# Altium schematic sheet HF_Control.SchDoc
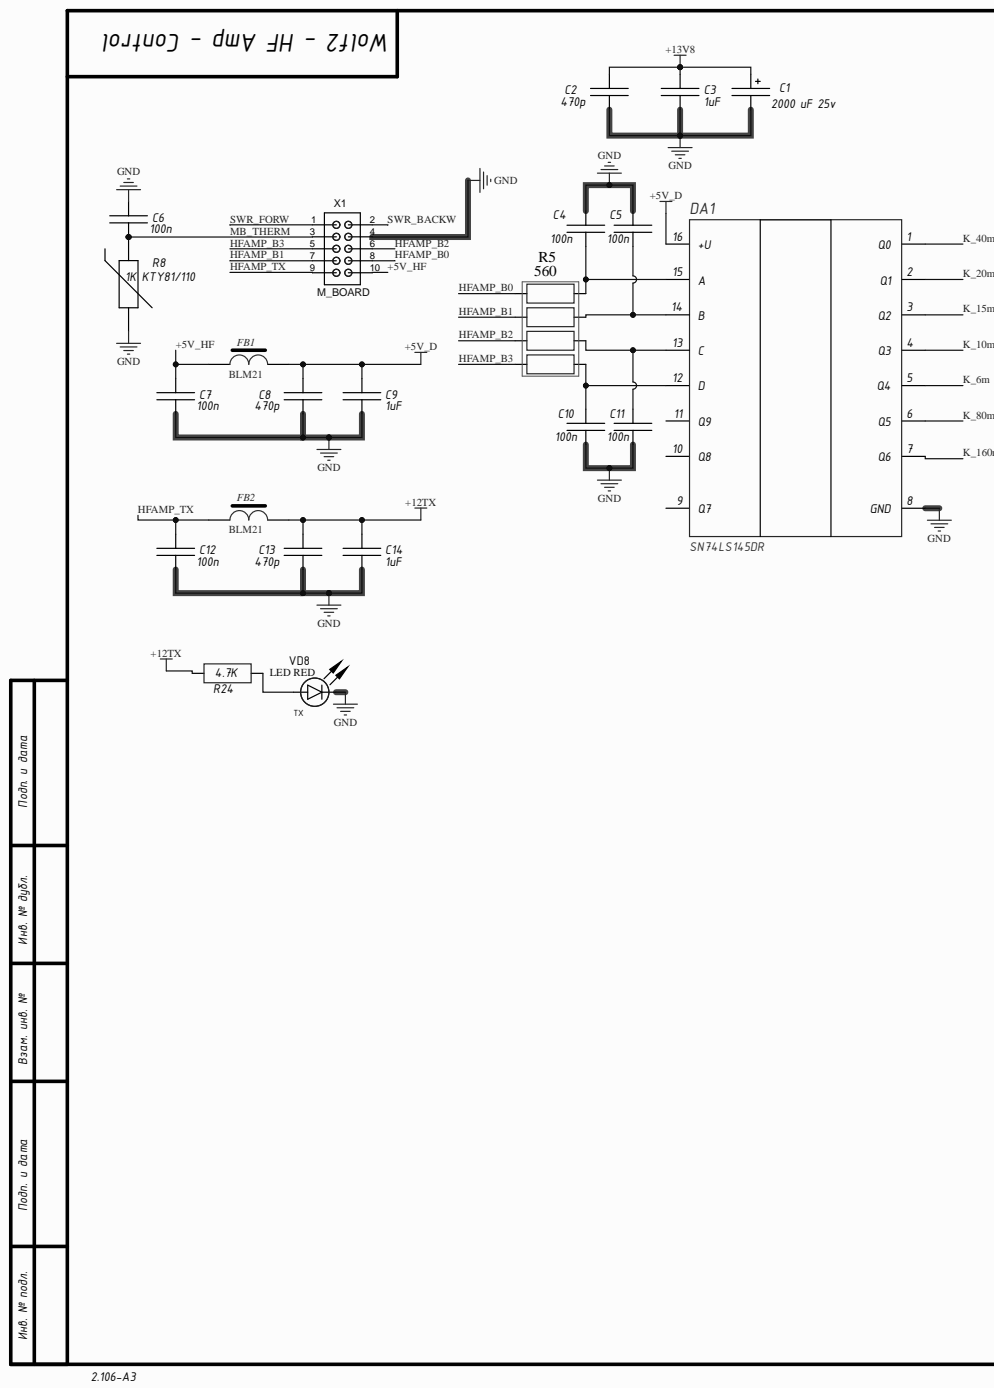
[diagram p0: HF_Control.SchDoc, left]
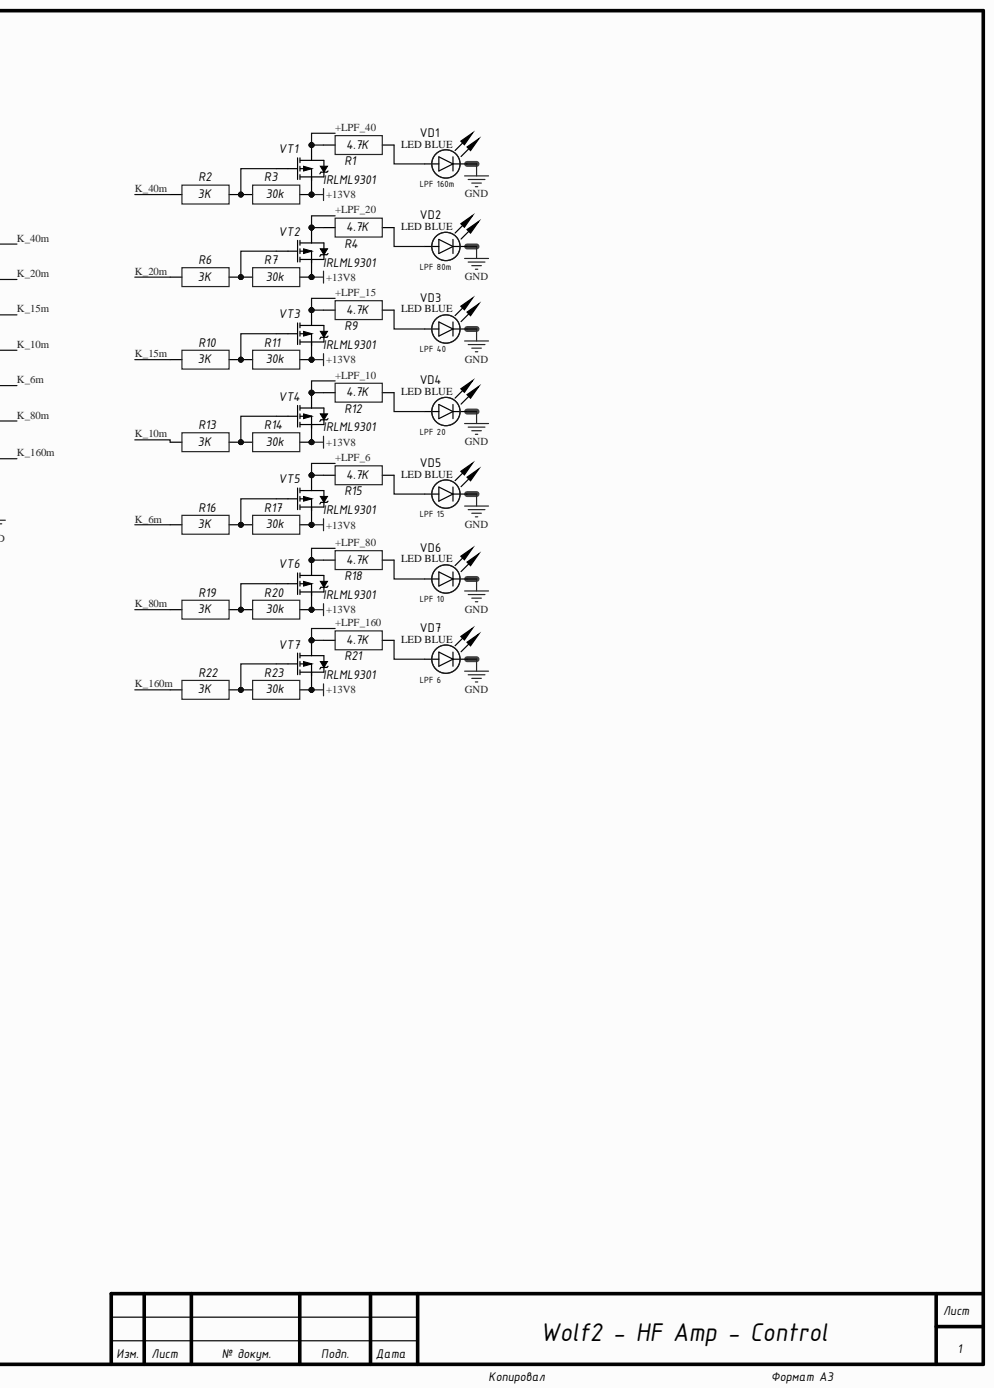
[diagram p1: HF_Control.SchDoc, right]

## Parts
C1  2000 uF 25v  [D13C5H20]
C2  470p  [CH0805C]
C3  1uF  [CH0805C]
C4  100n  [CH0805C]
C5  100n  [CH0805C]
C6  100n  [CH0805C]
C7  100n  [CH0805C]
C8  470p  [CH0805C]
C9  1uF  [CH0805C]
C10  100n  [CH0805C]
C11  100n  [CH0805C]
C12  100n  [CH0805C]
C13  470p  [CH0805C]
C14  1uF  [CH0805C]
DA1  SN74LS145DR  [16-SOP]
FB1  BLM21  [CH0805L]
FB2  BLM21  [CH0805L]
R1  4.7K  [CH0805R]
R2  3K  [CH0805R]
R3  30k  [CH0805R]
R4  4.7K  [CH0805R]
R5  560  [CAT16]
R6  3K  [CH0805R]
R7  30k  [CH0805R]
R8  1K KTY81/110  [CON: PBS-2]
R9  4.7K  [CH0805R]
R10  3K  [CH0805R]
R11  30k  [CH0805R]
R12  4.7K  [CH0805R]
R13  3K  [CH0805R]
R14  30k  [CH0805R]
R15  4.7K  [CH0805R]
R16  3K  [CH0805R]
R17  30k  [CH0805R]
R18  4.7K  [CH0805R]
R19  3K  [CH0805R]
R20  30k  [CH0805R]
R21  4.7K  [CH0805R]
R22  3K  [CH0805R]
R23  30k  [CH0805R]
R24  4.7K  [CH0805R]
VD1  LED BLUE  Color: LPF 160m  TypeDiode: LED  [LED: 0805B]
VD2  LED BLUE  Color: LPF 80m  TypeDiode: LED  [LED: 0805B]
VD3  LED BLUE  Color: LPF 40  TypeDiode: LED  [LED: 0805B]
VD4  LED BLUE  Color: LPF 20  TypeDiode: LED  [LED: 0805B]
VD5  LED BLUE  Color: LPF 15  TypeDiode: LED  [LED: 0805B]
VD6  LED BLUE  Color: LPF 10  TypeDiode: LED  [LED: 0805B]
VD7  LED BLUE  Color: LPF 6  TypeDiode: LED  [LED: 0805B]
VD8  LED RED  Color: TX  TypeDiode: LED  [LED: 0805R]
VT1  IRLML9301  [SOT23_132]
VT2  IRLML9301  [SOT23_132]
VT3  IRLML9301  [SOT23_132]
VT4  IRLML9301  [SOT23_132]
VT5  IRLML9301  [SOT23_132]
VT6  IRLML9301  [SOT23_132]
VT7  IRLML9301  [SOT23_132]
X1  M_BOARD  [CON: BH-10]

## Nets
+5V_D: C8.1 C9.1 DA1.16(+U) FB1.1
+12TX: C13.1 C14.1 FB2.1 R24.2
+13V8: C1.1 C2.1 C3.1 R3.1 R7.1 R11.1 R14.1 R17.1 R20.1 R23.1 VT1.2 VT2.2 VT3.2 VT4.2 VT5.2 VT6.2 VT7.2
GND: C1.2 C2.2 C3.2 C4.1 C5.1 C6.1 C7.2 C8.2 C9.2 C10.2 C11.2 C12.2 C13.2 C14.2 DA1.8(GND) R8.1 VD1.2 VD2.2 VD3.2 VD4.2 VD5.2 VD6.2 VD7.2 VD8.2 X1.4
+5V_HF: C7.1 FB1.2 X1.10
+LPF_6: R15.2 VT5.3
+LPF_10: R12.2 VT4.3
+LPF_15: R9.2 VT3.3
+LPF_20: R4.2 VT2.3
+LPF_40: R1.2 VT1.3
+LPF_80: R18.2 VT6.3
+LPF_160: R21.2 VT7.3
HFAMP_B0: R5.1 X1.8
HFAMP_B1: R5.2 X1.7
HFAMP_B2: R5.3 X1.6
HFAMP_B3: R5.4 X1.5
HFAMP_TX: C12.1 FB2.2 X1.9
K_6m: DA1.5(Q4) R16.2
K_10m: DA1.4(Q3) R13.2
K_15m: DA1.3(Q2) R10.2
K_20m: DA1.2(Q1) R6.2
K_40m: DA1.1(Q0) R2.2
K_80m: DA1.6(Q5) R19.2
K_160m: DA1.7(Q6) R22.2
MB_THERM: C6.2 R8.2 X1.3
SWR_BACKW: X1.2
SWR_FORW: X1.1
NetC4_2: C4.2 DA1.15(A) R5.5
NetC5_2: C5.2 DA1.14(B) R5.6
NetC10_1: C10.1 DA1.12(D) R5.8
NetC11_1: C11.1 DA1.13(C) R5.7
NetR1_1: R1.1 VD1.1
NetR2_1: R2.1 R3.2 VT1.1
NetR4_1: R4.1 VD2.1
NetR6_1: R6.1 R7.2 VT2.1
NetR9_1: R9.1 VD3.1
NetR10_1: R10.1 R11.2 VT3.1
NetR12_1: R12.1 VD4.1
NetR13_1: R13.1 R14.2 VT4.1
NetR15_1: R15.1 VD5.1
NetR16_1: R16.1 R17.2 VT5.1
NetR18_1: R18.1 VD6.1
NetR19_1: R19.1 R20.2 VT6.1
NetR21_1: R21.1 VD7.1
NetR22_1: R22.1 R23.2 VT7.1
NetR24_1: R24.1 VD8.1

Not connected: DA1 9(Q7) 10(Q8) 11(Q9)

## Library data of the parts
C1 = AlumCap: Component Kind: Standard; Library Name: Discrete.SchLib
C2-C14 = Capacitor: Component Kind: Standard; Library Name: Discrete.SchLib
DA1 = SN74LS145N: Component Kind: Standard; Library Name: Digital.SchLib
FB1 FB2 = FB-1: Component Kind: Standard; Library Name: Discrete.SchLib
R1-R4 R6 R7 R9-R24 = Resistor: Component Kind: Standard; Library Name: Discrete.SchLib
R5 = CAT10-0804: Component Kind: Standard; Library Name: Discrete.SchLib
R8 = NTC: Component Kind: Standard; Library Name: Discrete.SchLib
VD1-VD8 = LED_single: ValueManufacturerPartNumber: LED, *, *, *, -55...+150°C; Component Kind: Standard; Library Name: Diode.SchLib; Temperature: -55...+150°C
VT1-VT7 = IRLML9301: Mosfet; Component Kind: Standard; Library Name: Transistor.SchLib
X1 = IDC10: 2*5 Pin; Component Kind: Standard
